# Altium schematic sheet Power Stage Schematic.SchDoc("Power Stage Schematic")
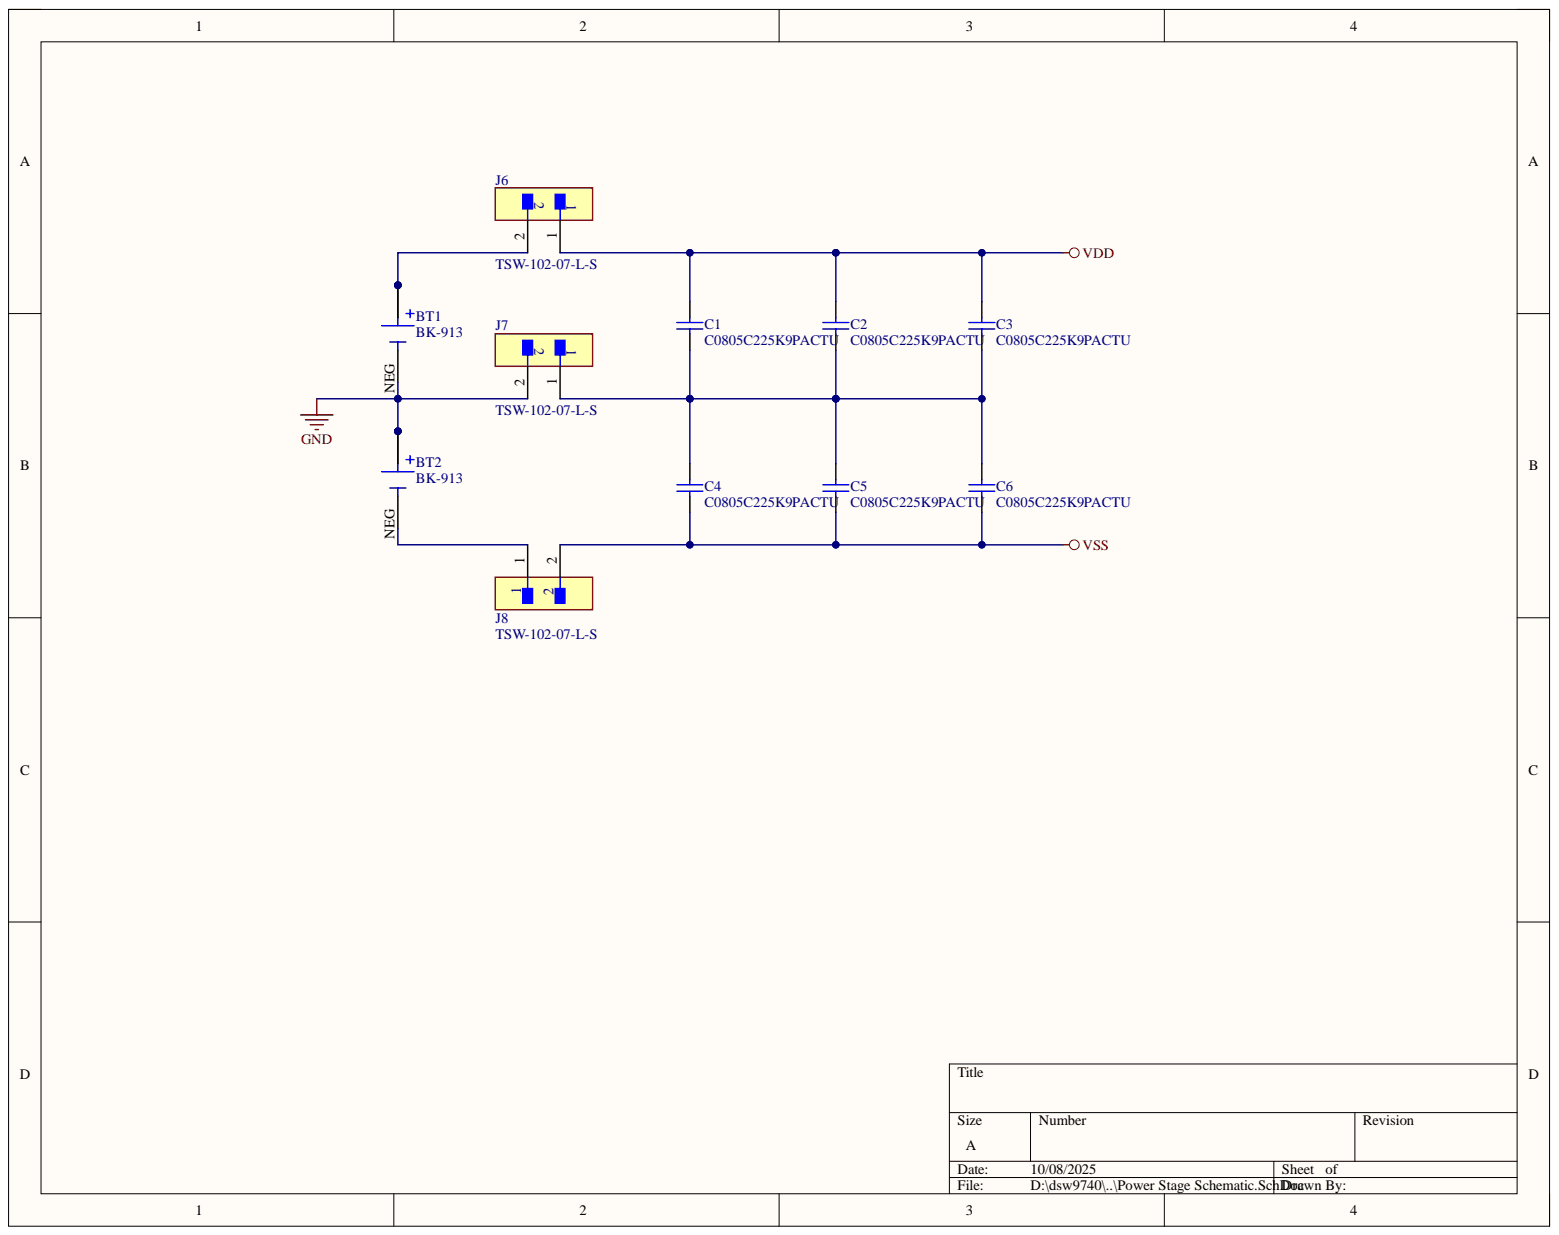
[diagram p0: Power Stage Schematic.SchDoc]

## Parts
BT1  BK-913  [BAT_BK-913]
BT2  BK-913  [BAT_BK-913]
C1  C0805C225K9PACTU  [CAPC2013X145X50ML20T25]
C2  C0805C225K9PACTU  [CAPC2013X145X50ML20T25]
C3  C0805C225K9PACTU  [CAPC2013X145X50ML20T25]
C4  C0805C225K9PACTU  [CAPC2013X145X50ML20T25]
C5  C0805C225K9PACTU  [CAPC2013X145X50ML20T25]
C6  C0805C225K9PACTU  [CAPC2013X145X50ML20T25]
J6  TSW-102-07-L-S  [FP-TSW-102-07-L-S-MFG]
J7  TSW-102-07-L-S  [FP-TSW-102-07-L-S-MFG]
J8  TSW-102-07-L-S  [FP-TSW-102-07-L-S-MFG]

## Nets
GND: BT1.NEG BT2.S+1 BT2.S+2 J7.2
VDD: C1.2 C2.2 C3.2 J6.1
VSS: C4.1 C5.1 C6.1 J8.2
NetBT1_S+1: BT1.S+1 BT1.S+2 J6.2
NetBT2_NEG: BT2.NEG J8.1
NetC1_1: C1.1 C2.1 C3.1 C4.2 C5.2 C6.2 J7.1

## Library data of the parts
BT1 BT2 = BK-913: Battery Retainer Coin, 20.0mm 1 Cell PC Pin; MF: MPD; Check_prices: https://www.snapeda.com/parts/BK-913/Memory+Protection+Devices/view-part/?ref=eda; Component Kind: Standard; Library Name: BK-913.SchLib; MP: BK-913; Package: Non-Standard MPD; SnapEDA_Link: https://www.snapeda.com/parts/BK-913/Memory+Protection+Devices/view-part/?ref=snap
C1-C6 = CMP-1036-04819-2: Manufacturer: Kemet; Component Kind: Standard; Library Name: Altium Content Vault; Part Number: C0805C225K9PACTU
J6-J8 = CMP-02766-001428-1: CONN HEADER VERT 2POS 2.54MM; Connector Type: Male Pin; Current Rating: 7.6A; Gender: Male; Max Voltage Rating (AC): 550V; Number of Contacts: 2; Color: Black; Component Kind: Standard; Contact Material: Bronze; Contact Mating Length: 0.23inch; Contact Plating: Gold; Contact Type: Male Pin; Depth: 2.54mm; Housing Color: Black; Housing Material: Polyester; Lead Free: Lead Free; Length: 5.08mm; Library Name: Altium Content Vault; Max Current Rating: 7.6A; Max Operating Temperature: 125°C; Min Operating Temperature: -55°C; Mount: Through Hole; Number of Positions: 2; Number of Rows: 1; Orientation: Straight; Package Quantity: 1; Packaging: Bulk; Pitch: 2.54mm; Radiation Hardening: No; REACH SVHC: No SVHC; RoHS Compliant: Yes; Series: TSW; Termination: Solder; Voltage Rating: 550V; Width: 2.54mm
